# Altium schematic sheet MCU.SchDoc(MCU)
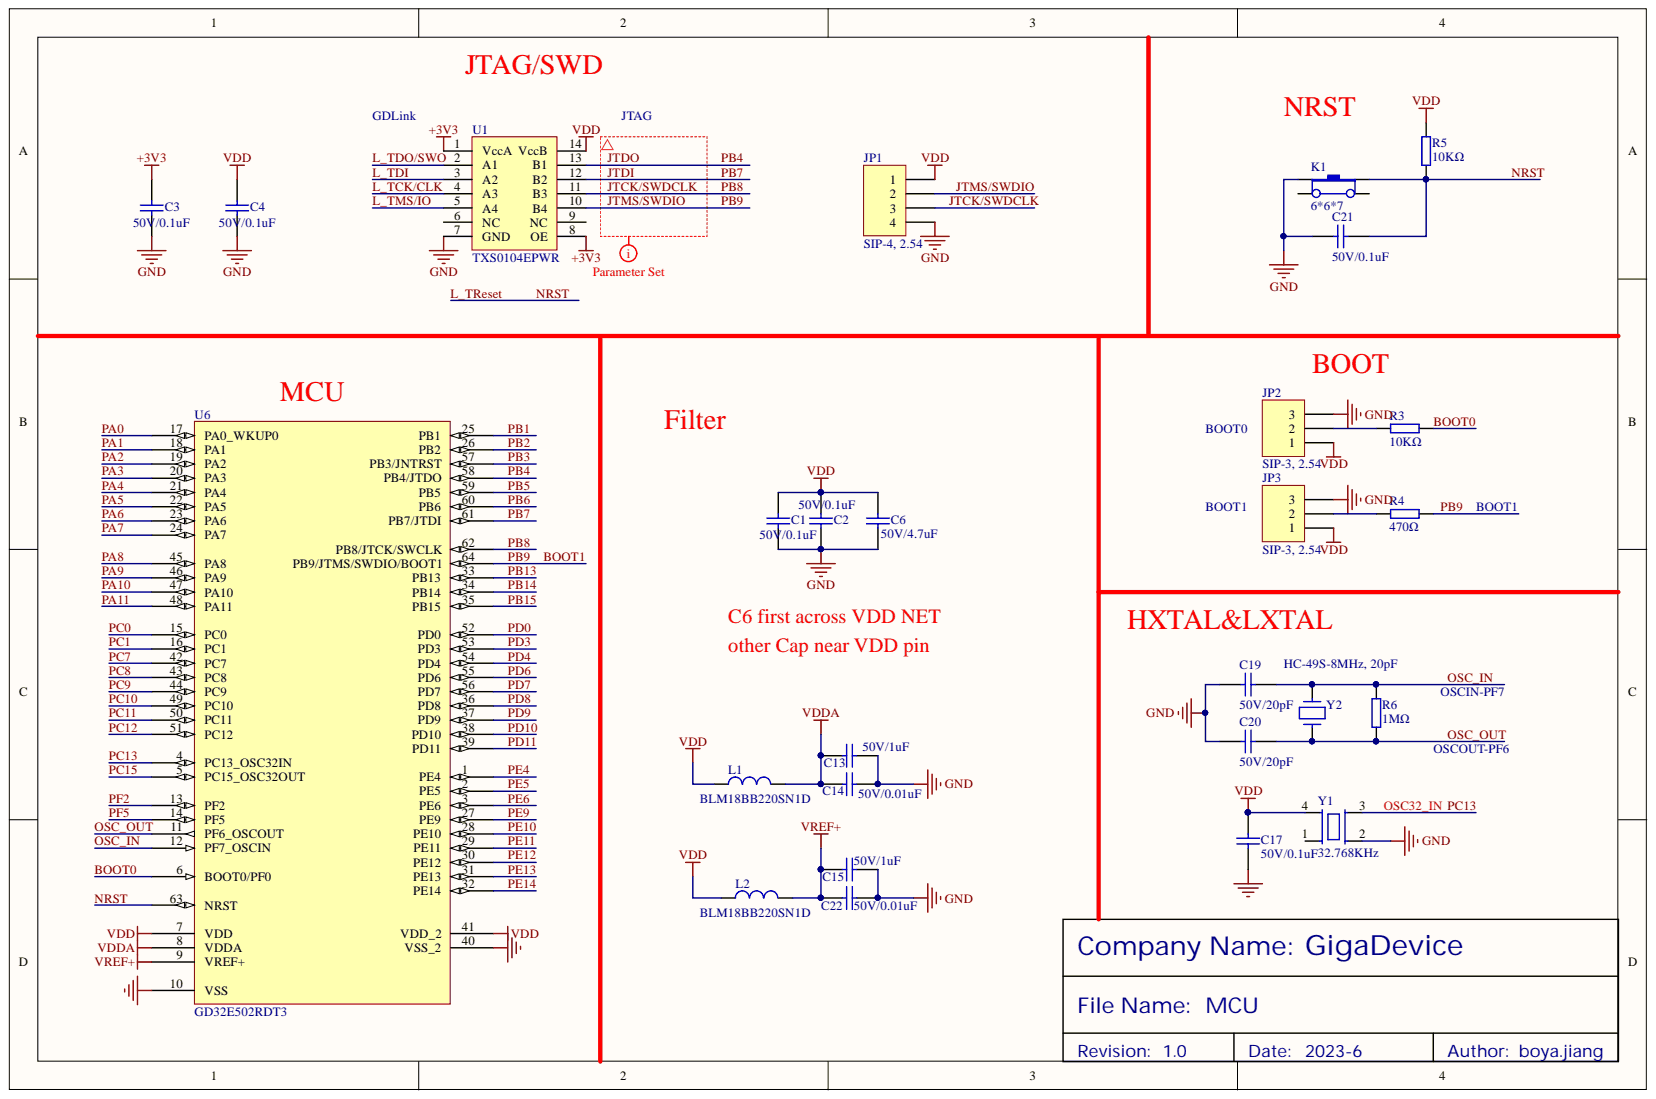
[diagram p0: MCU.SchDoc]

## Parts
C1  50V/0.1uF  [0603-C]
C2  50V/0.1uF  [0603-C]
C3  50V/0.1uF  [0603-C]
C4  50V/0.1uF  [0603-C]
C6  50V/4.7uF  [0603-C]
C13  50V/1uF  [0603-C]
C14  50V/0.01uF  [0603-C]
C15  50V/1uF  [0603-C]
C17  50V/0.1uF  [0603-C]
C19  50V/20pF  [0603-C]
C20  50V/20pF  [0603-C]
C21  50V/0.1uF  [0603-C]
C22  50V/0.01uF  [0603-C]
JP1  SIP-4, 2.54  [SIP-4]
JP2  SIP-3, 2.54  [SIP-3]
JP3  SIP-3, 2.54  [SIP-3]
K1  6*6*7  [K-1102B]
L1  BLM18BB220SN1D  [0603-R]
L2  BLM18BB220SN1D  [0603-R]
R3  10KΩ  [0603-R]
R4  470Ω  [0603-R]
R5  10KΩ  [0603-R]
R6  1MΩ  [0603-R]
U1  TXS0104EPWR  [TSSOP-14]
U6  GD32E502RDT3  [LQFP64_10x10x1.6]
Y1  32.768KHz  [XO75]
Y2  HC-49S-8MHz, 20pF  [HC-49S]

## Nets
+3V3: C3.2 U1.1(VccA) U1.8(OE)
GND: C1.1 C2.1 C3.1 C4.1 C6.1 C13.1 C14.1 C15.1 C17.2 C19.1 C20.1 C21.2 C22.1 JP1.4 JP2.3 JP3.3 K1.1 U1.7(GND) U6.10(VSS) U6.40(VSS_2) Y1.2
VDD: C1.2 C2.2 C4.2 C6.2 C17.1 JP1.1 JP2.1 JP3.1 L1.1 L2.1 R5.2 U1.14(VccB) U6.7(VDD) U6.41(VDD_2) Y1.4
VDDA: C13.2 C14.2 L1.2 U6.8(VDDA)
VREF+: C15.2 C22.2 L2.2 U6.9(VREF+)
BOOT0: R3.1 U6.6(BOOT0/PF0)
BOOT1: JP1.2 R4.1 U1.10(B4) U6.64(PB9/JTMS/SWDIO/BOOT1)
JTCK/SWDCLK: JP1.3 U1.11(B3) U6.62(PB8/JTCK/SWCLK)
JTDI: U1.12(B2) U6.61(PB7/JTDI)
JTDO: U1.13(B1) U6.58(PB4/JTDO)
L_TCK/CLK: U1.4(A3)
L_TDI: U1.3(A2)
L_TDO/SWO: U1.2(A1)
L_TMS/IO: U1.5(A4)
L_TReset: C21.1 K1.3 R5.1 U6.63(NRST)
OSC_IN: C19.2 R6.2 U6.12(PF7_OSCIN) Y2.2
OSC_OUT: C20.2 R6.1 U6.11(PF6_OSCOUT) Y2.1
PA0: U6.17(PA0_WKUP0)
PA1: U6.18(PA1)
PA2: U6.19(PA2)
PA3: U6.20(PA3)
PA4: U6.21(PA4)
PA5: U6.22(PA5)
PA6: U6.23(PA6)
PA7: U6.24(PA7)
PA8: U6.45(PA8)
PA9: U6.46(PA9)
PA10: U6.47(PA10)
PA11: U6.48(PA11)
PB1: U6.25(PB1)
PB2: U6.26(PB2)
PB3: U6.57(PB3/JNTRST)
PB5: U6.59(PB5)
PB6: U6.60(PB6)
PB13: U6.33(PB13)
PB14: U6.34(PB14)
PB15: U6.35(PB15)
PC0: U6.15(PC0)
PC1: U6.16(PC1)
PC7: U6.42(PC7)
PC8: U6.43(PC8)
PC9: U6.44(PC9)
PC10: U6.49(PC10)
PC11: U6.50(PC11)
PC12: U6.51(PC12)
PC13: U6.4(PC13_OSC32IN) Y1.3
PC15: U6.5(PC15_OSC32OUT)
PD0: U6.52(PD0)
PD3: U6.53(PD3)
PD4: U6.54(PD4)
PD6: U6.55(PD6)
PD7: U6.56(PD7)
PD8: U6.36(PD8)
PD9: U6.37(PD9)
PD10: U6.38(PD10)
PD11: U6.39(PD11)
PE4: U6.1(PE4)
PE5: U6.2(PE5)
PE6: U6.3(PE6)
PE9: U6.27(PE9)
PE10: U6.28(PE10)
PE11: U6.29(PE11)
PE12: U6.30(PE12)
PE13: U6.31(PE13)
PE14: U6.32(PE14)
PF2: U6.13(PF2)
PF5: U6.14(PF5)
NetJP2_2: JP2.2 R3.2
NetJP3_2: JP3.2 R4.2
N00132:

Not connected: K1 2 4; U1 6(NC) 9(NC); Y1 1

## Library data of the parts
C1-C4 C6 C13-C15 C17 C21 C22 = 50V/0.1uF: 表贴电容; Component Kind: Standard; Library Name: KLTC-电容.SchLib
C19 C20 = 50V/20pF: 表贴电容; Component Kind: Standard; Library Name: KLTC-电容.SchLib
JP1 = HEADER 4: 单排插针; Component Kind: Standard; Library Name: KLTC-按键及接插件.SchLib
JP2 JP3 = HEADER 3: 单排插针; Component Kind: Standard; Library Name: KLTC-按键及接插件.SchLib
K1 = K-1102B: 普通6X6X7直插按键; Component Kind: Standard; Library Name: KLTC-按键及接插件.SchLib
L1 L2 = BLM21AG221SN1D: 表贴磁珠; Component Kind: Standard; Library Name: KLTC-电感及磁珠.SchLib
R3-R5 = 10KΩ: 表贴电阻; Component Kind: Standard; Library Name: KLTC-电阻.SchLib
R6 = 1MΩ: 表贴电阻; Component Kind: Standard; Library Name: KLTC-电阻.SchLib
U1 = TXS0104: 表贴电平转换芯片; Library Name: Temp.SchLib; Component Kind: Standard
U6 = GD32E502Rx: 表贴MCU芯片; Component Kind: Standard; Library Name: boyajiang.SchLib
Y1 = XO75-NBBTA-22.1184MHz: 表贴有源晶振; Component Kind: Standard; Library Name: KLTC-晶振.SchLib
Y2 = HC-49S-G20SSA-8MHz: 直插晶振; Component Kind: Standard; Library Name: KLTC-晶振.SchLib
